# Altium schematic sheet USB.SchDoc("USB PORT")
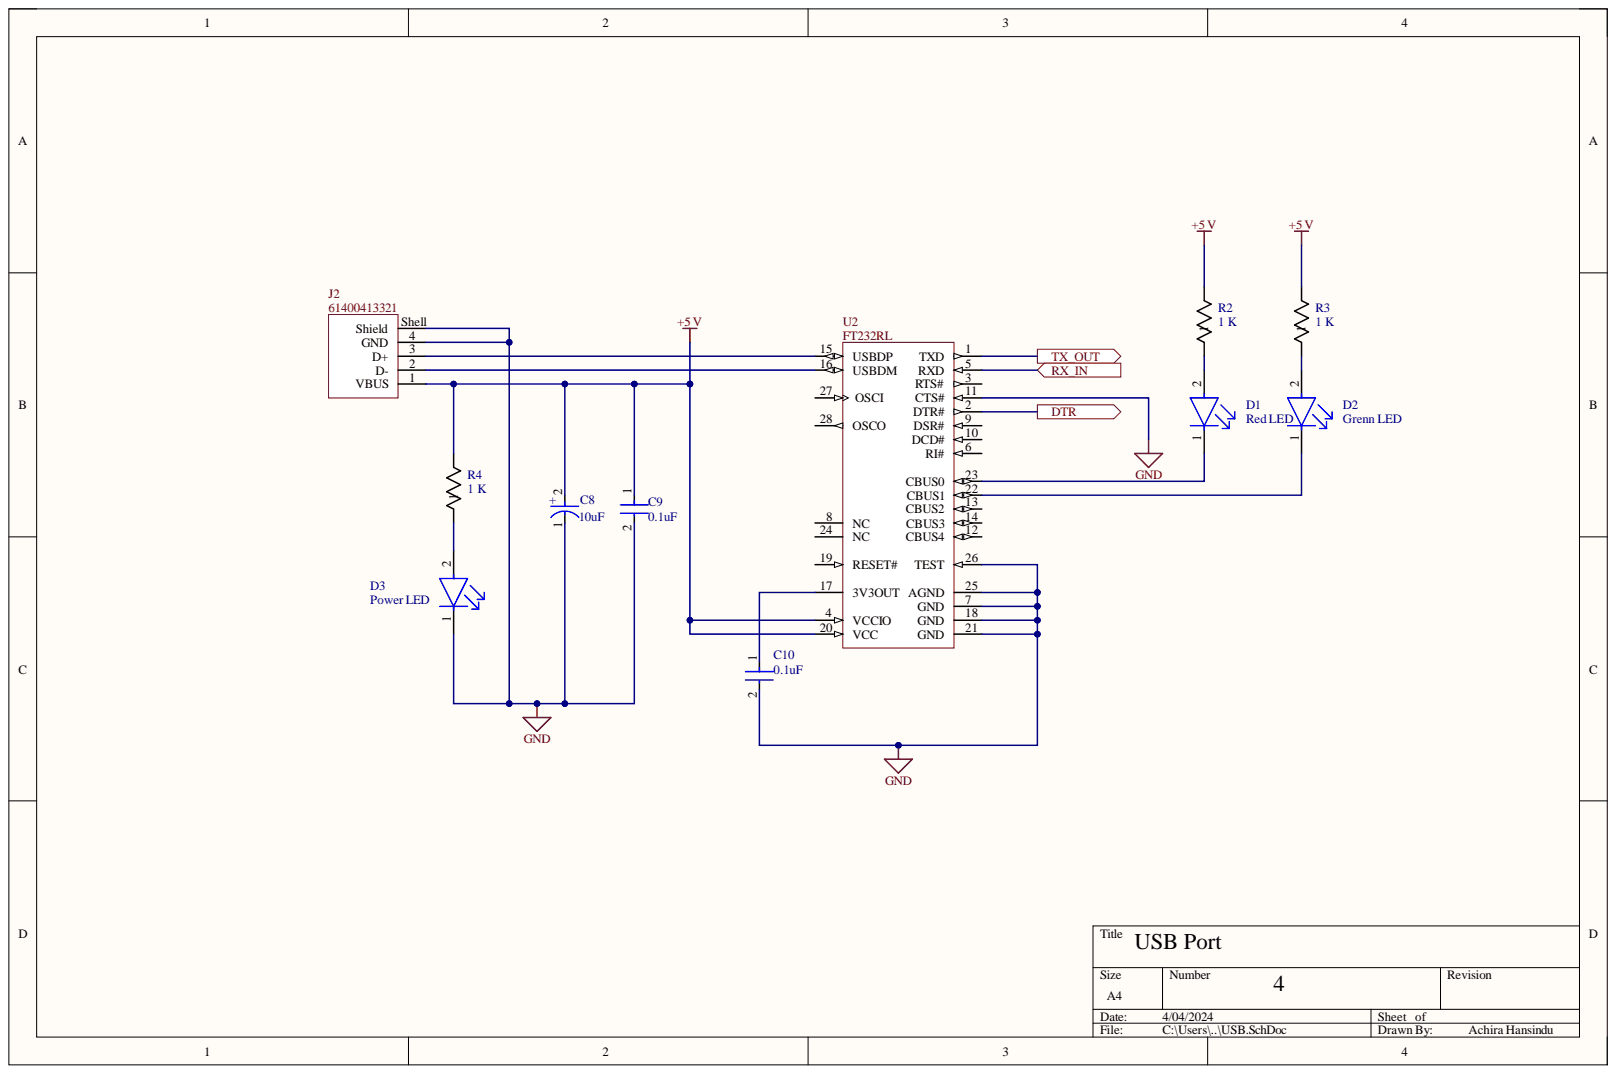
[diagram p0: USB.SchDoc]

## Parts
C8  Capacitance: 10uF  [FP-SC-MFG]  (hidden comment: EEE1VA100SR)
C9  Capacitance: 0.1uF  [FP-GRM31M-0_15-IPC_A]  (hidden comment: GRM31M1X1H104JA01L)
C10  Capacitance: 0.1uF  [FP-GRM31M-0_15-IPC_A]  (hidden comment: GRM31M1X1H104JA01L)
D1  HSMG-C150  [FP-HSMG-C150-MFG]  (value not drawn)
D2  Color: Grenn LED  [FP-HSMG-C150-MFG]  (hidden comment: HSMG-C150)
D3  HSMG-C150  [FP-HSMG-C150-MFG]  (value not drawn)
J2  61400413321  [61400413321]
R2  value 1 K  [RES_RCC1206_VIS]  (hidden comment: RCC12061K00FKEA)
R3  value 1 K  [RES_RCC1206_VIS]  (hidden comment: RCC12061K00FKEA)
R4  value 1 K  [RES_RCC1206_VIS]  (hidden comment: RCC12061K00FKEA)
U2  FT232RL  [FTDI-SSOP-28_M]

## Ports
DTR, RX_IN, TX_OUT

## Nets
+5 V: C8.2 C9.1 J2.1(VBUS) R2.2 R3.2 R4.2 U2.4(VCCIO) U2.20(VCC)
GND: C8.1 C9.2 C10.2 D3.1 J2.4(GND) J2.Shell(Shield) U2.7(GND) U2.11(CTS#) U2.18(GND) U2.21(GND) U2.25(AGND) U2.26(TEST)
DTR: U2.2(DTR#) ; port DTR
RX_IN: U2.5(RXD) ; port RX_IN
TX_OUT: U2.1(TXD) ; port TX_OUT
NetC10_1: C10.1 U2.17(3V3OUT)
NetD1_1: D1.1 U2.23(CBUS0)
NetD1_2: D1.2 R2.1
NetD2_1: D2.1 U2.22(CBUS1)
NetD2_2: D2.2 R3.1
NetD3_2: D3.2 R4.1
NetJ2_2: J2.2(D-) U2.16(USBDM)
NetJ2_3: J2.3(D+) U2.15(USBDP)
NetU2_3: U2.3(RTS#)
NetU2_6: U2.6(RI#)
NetU2_8: U2.8(NC)
NetU2_9: U2.9(DSR#)
NetU2_10: U2.10(DCD#)
NetU2_12: U2.12(CBUS4)
NetU2_13: U2.13(CBUS2)
NetU2_14: U2.14(CBUS3)
NetU2_19: U2.19(RESET#)
NetU2_24: U2.24(NC)
NetU2_27: U2.27(OSCI)
NetU2_28: U2.28(OSCO)

## Library data of the parts
C8 = CMP-05427-000087-1: CAP ALUM 10UF 20% 35V SMD; Lead Length: 2.2mm; Leakage Current: 3uA; Life (Hours): 2000Hour; Ripple Current: 30mA; Case/Package: Radial; Component Kind: Standard; Construction: Cylindrical; Contact Plating: Tin; Diameter: 5mm; Height: 5.4mm; Height - Seated (Max): 0.213inch; Lead Pitch: 1.5mm; Length: 5.5mm; Library Name: Altium Content Vault; Max Operating Temperature: 85°C; Min Operating Temperature: -40°C; Mount: Surface Mount; Number of Pins: 2; Package Quantity: 1; Packaging: Tape and Reel; Radiation Hardening: No; REACH SVHC: No SVHC; Ripple Current (AC): 30mA; RoHS Compliant: Yes; Series: S; Termination: SMD/SMT; Tolerance: 20%; Voltage: 35V; Voltage Rating: 35V; Voltage Rating (DC): 35V; Width: 5.3mm
C9 C10 = CMP-06035-007547-1: Chip Multilayer Ceramic Capacitors for General Purpose, 1206, 0.10uF, SL, +350/-1000ppm/°C, 5%, 50V; Dissipation Factor: 0.025%; Case Code (Imperial): 1206; Case Code (Metric): 3216; Case/Package: 1206; Component Kind: Standard; Depth: 1.6mm; Dielectric Material: Ceramic; Height: 1.15mm; Length: 0.126inch; Library Name: Altium Content Vault; Max Operating Temperature: 125°C; Min Operating Temperature: -55°C; Mount: Surface Mount; Packaging: Tape and Reel; Temperature Coefficient: -1000ppm/°C; Termination: SMD/SMT; Thickness: 0.049inch; Tolerance: 5%; Voltage: 50V; Voltage Rating: 50V; Voltage Rating (DC): 50V; Width: 0.063inch
D1 = CMP-2000-05061-2: LED GREEN DIFFUSED 1206 SMD; Manufacturer: Broadcom; Color: Red LED; Forward Current: 20mA; Forward Voltage: 2.2V; Led Orientation: Top View; Luminous Intensity: 15mcd; Max Junction Temp: +95°C; Peak Emmision Wavelength: 570nm; Power Dissipation: 65mW; Reverse Voltage: 5V; Viewing Angle: 170°; Wavelength: 572nm; Automotive: No; Category: Diode; Colour: Green; Component Kind: Standard; ComponentLink2Description: Datasheet; ComponentLink2URL: https://docs.broadcom.com/docs/AV02-0551EN; Device Class L1: Optoelectronics; Device Class L2: LEDs; Device Class L3: unset; Digikey Description: LED GREEN DIFFUSED 1206 SMD; Height: 1.2mm; Ipc Land Pattern Name: DIOCP320160X55; Lead Free: Yes; Library Name: Altium Content Vault; Manufacturer Part Number: HSMG-C150; Mouser Description: LED Uni-Color Green 570nm 2-Pin Chip LED T/R; Octopart: https://octopart.com/hsmg-c150-broadcom-71131304; Package: HSMG-C150; RoHS: TRUE; Temperature: -40°C to +85°C; Temperature Range High: +85°C; Temperature Range Low: -40°C
D2 = CMP-2000-05061-2: LED GREEN DIFFUSED 1206 SMD; Manufacturer: Broadcom; Forward Current: 20mA; Forward Voltage: 2.2V; Led Orientation: Top View; Luminous Intensity: 15mcd; Max Junction Temp: +95°C; Peak Emmision Wavelength: 570nm; Power Dissipation: 65mW; Reverse Voltage: 5V; Viewing Angle: 170°; Wavelength: 572nm; Automotive: No; Category: Diode; Colour: Green; Component Kind: Standard; ComponentLink2Description: Datasheet; ComponentLink2URL: https://docs.broadcom.com/docs/AV02-0551EN; Device Class L1: Optoelectronics; Device Class L2: LEDs; Device Class L3: unset; Digikey Description: LED GREEN DIFFUSED 1206 SMD; Height: 1.2mm; Ipc Land Pattern Name: DIOCP320160X55; Lead Free: Yes; Library Name: Altium Content Vault; Manufacturer Part Number: HSMG-C150; Mouser Description: LED Uni-Color Green 570nm 2-Pin Chip LED T/R; Octopart: https://octopart.com/hsmg-c150-broadcom-71131304; Package: HSMG-C150; RoHS: TRUE; Temperature: -40°C to +85°C; Temperature Range High: +85°C; Temperature Range Low: -40°C
D3 = CMP-2000-05061-2: LED GREEN DIFFUSED 1206 SMD; Manufacturer: Broadcom; Color: Power LED; Forward Current: 20mA; Forward Voltage: 2.2V; Led Orientation: Top View; Luminous Intensity: 15mcd; Max Junction Temp: +95°C; Peak Emmision Wavelength: 570nm; Power Dissipation: 65mW; Reverse Voltage: 5V; Viewing Angle: 170°; Wavelength: 572nm; Automotive: No; Category: Diode; Colour: Green; Component Kind: Standard; ComponentLink2Description: Datasheet; ComponentLink2URL: https://docs.broadcom.com/docs/AV02-0551EN; Device Class L1: Optoelectronics; Device Class L2: LEDs; Device Class L3: unset; Digikey Description: LED GREEN DIFFUSED 1206 SMD; Height: 1.2mm; Ipc Land Pattern Name: DIOCP320160X55; Lead Free: Yes; Library Name: Altium Content Vault; Manufacturer Part Number: HSMG-C150; Mouser Description: LED Uni-Color Green 570nm 2-Pin Chip LED T/R; Octopart: https://octopart.com/hsmg-c150-broadcom-71131304; Package: HSMG-C150; RoHS: TRUE; Temperature: -40°C to +85°C; Temperature Range High: +85°C; Temperature Range Low: -40°C
J2 = CMP-1502-03246-2: NexarPartId: 18818176; Connector Type: USB; Contact Resistance: 20mΩ; Current Rating: 1.5A; Gender: Receptacle; Insulation Resistance: 1GΩ; Number of Contacts: 4; Number of Ports: 1; Operating Supply Voltage: 30V; USB Standard: USB 2.0; Color: White; Component Kind: Standard; Contact Material: Gold; Contact Plating: Gold; Features: Shielded; Height: 16.3mm; Insulation Material: PBT; Lead Free: Lead Free; Length: 14.8mm; Library Name: Altium Content Vault; Max Operating Temperature: 105°C; Min Operating Temperature: -40°C; Mount: PCB, Through Hole, Vertical; Number of Pins: 4; Number of Positions: 4; Orientation: Vertical; REACH SVHC: Unknown; RoHS: Compliant; Termination: Solder; Voltage Rating: 30V; Width: 19.15mm
R2-R4 = CMP-05494-116077937-1: RCC12061K00FKEA Resistor, 1K, 1%, 0.5W, 1206, THICK FILM;; Component Kind: Standard; Library Name: Altium Content Vault; NexarPartId: 116077937
U2 = CMP-2000-05029-1: IC USB FS SERIAL UART 28-SSOP; Manufacturer: FTDI; Maximum Data Rate: 3MBd; Number of Channels per Chip: 1; Receive FIFO: 256B; Transmit FIFO: 128B; Component Kind: Standard; Datasheet URL: http://datasheet.octopart.com/FT232RL-FTDI-datasheet-120501.pdf; Datasheet Version: Rev. 1.04, 02/2006; Dimensions: 10.2x5.3x1.75mm; Height: 1.75mm; Length: 10.2mm; Library Name: Altium Content Vault; Manufacturer URL: http://www.ftdichip.com/; Maximum Operating Temperature: +85degC; Minimum Operating Temperature: -40degC; Mounting Type: SurfaceMount; Package Type: SSOP; Pin Count: 28; Width: 5.3mm
